# Altium schematic sheet Status_LEDs.SchDoc
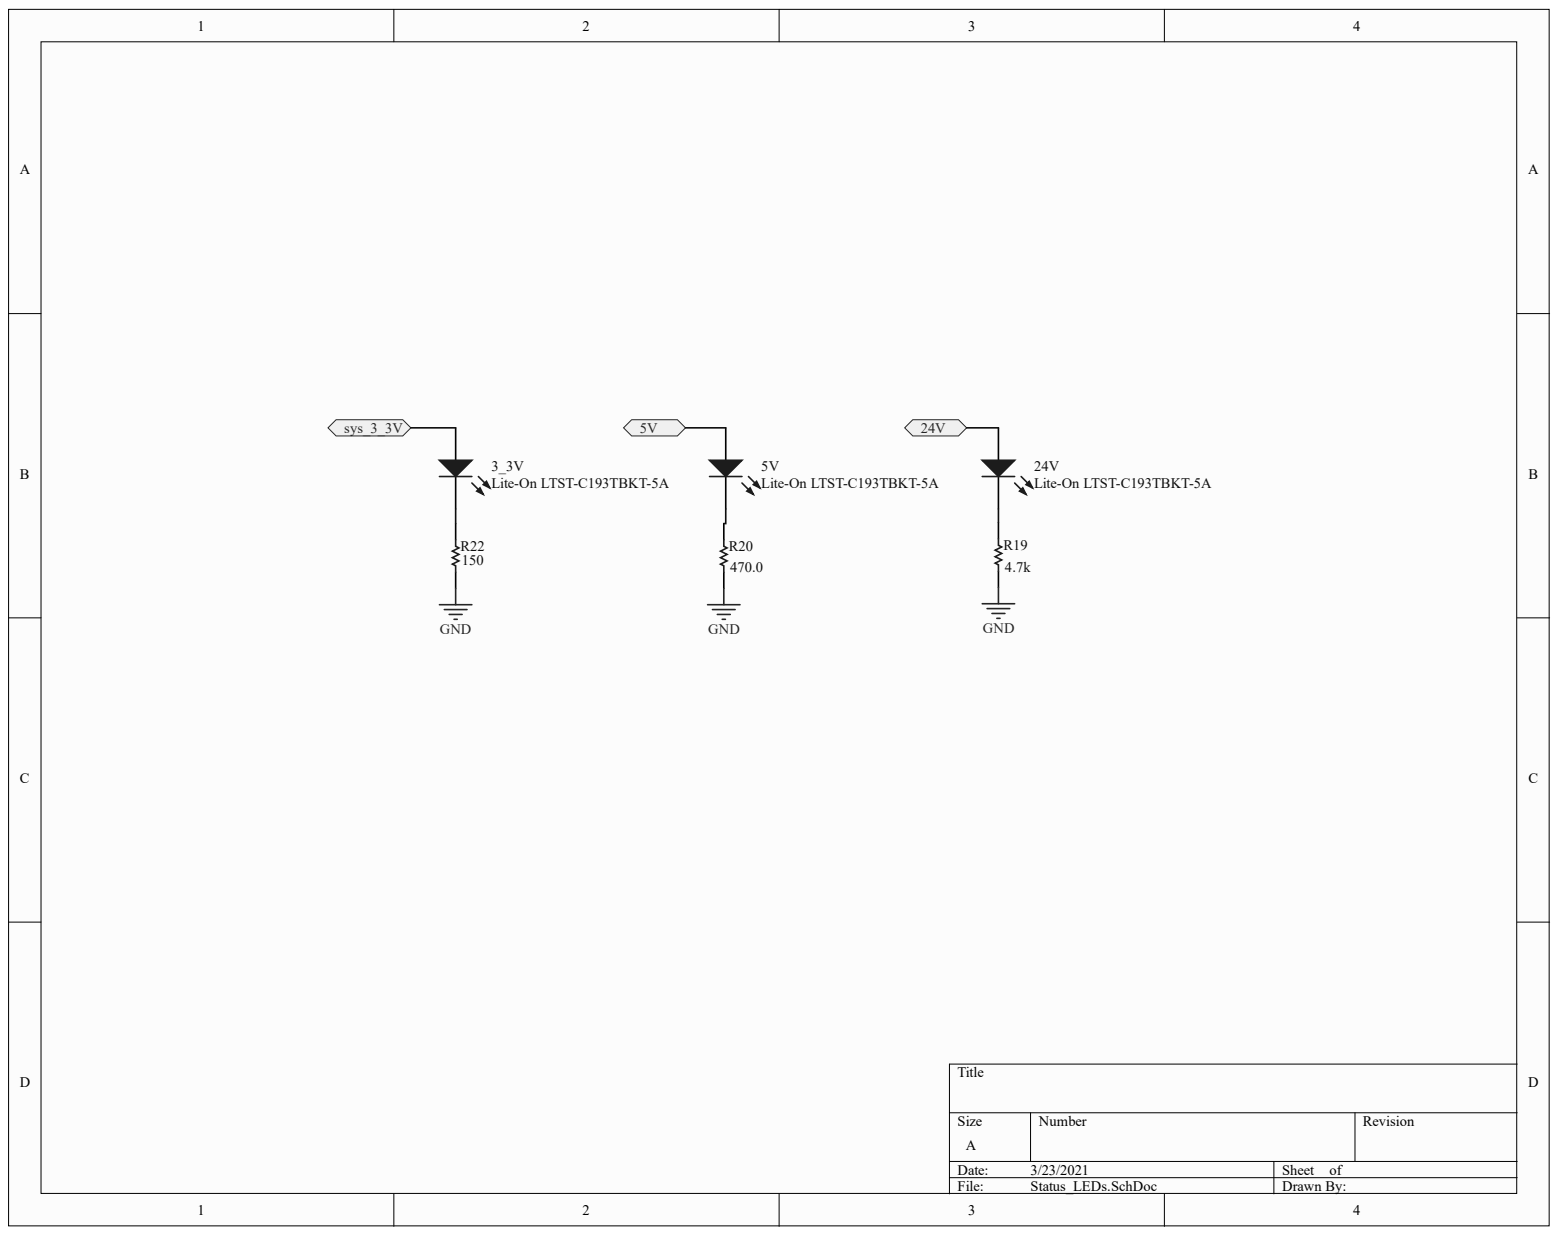
[diagram p0: Status_LEDs.SchDoc]

## Parts
3_3V  Lite-On LTST-C193TBKT-5A  [PCB-0603-BoxOverlay]
5V  Lite-On LTST-C193TBKT-5A  [PCB-0603-BoxOverlay]
24V  Lite-On LTST-C193TBKT-5A  [PCB-0603-BoxOverlay]
R19  value 4.7k  [PCB-g8ucq7vw1s2sdhfr4zem-1]  (hidden comment: Res1)
R20  value 470.0  [RESC1609X55X30ML10T20]  (hidden comment: Res1)
R22  value 150  [PCB-q471cgnjbrf9ki9faqwr-1]  (hidden comment: Res1)

## Ports
5V, 24V, SYS_3_3V

## Nets
GND: R19.1 R20.1 R22.1
SYS_3_3V: 3_3V.2 ; port SYS_3_3V
5V: 5V.2 ; port 5V
24V: 24V.2 ; port 24V
Net3_3V_1: 3_3V.1 R22.2
Net5V_1: 5V.1 R20.2
Net24V_1: 24V.1 R19.2

## Library data of the parts
3_3V 5V 24V = CMP-abba620d38e3747d-7: Blue 1.6 x 0.8 mm (0603) 130 º Intensity Min 11.2 mcd Typical 15 mcd SMT LED; Lead-Free Status: Lead Free; Colour: Blue; Component Kind: Standard; Library Name: CommunityVault; Manufacturer Part Number: LTST-C193TBKT-5A; OctopartID: abba620d38e3747d; Octopart URL: http://octopart.com/ltst-c193tbkt-5a-lite-on-12432959; RoHS: Compliant
R19 = CMP-011ec9310fadded9-4: Res Thick Film 0603 4.7K Ohm 1% 0.1W(1/10W) ±100ppm/C Pad SMD Automotive T/R; Resistance: 4.70 kΩ; Case/Package: 0603; Component Kind: Standard; Library Name: CommunityVault; Mounting Style: Surface Mount
R20 = CMP-120ade6ce4e1cdcd-1: Res Thick Film 0603 470 Ohm 5% 0.1W(1/10W) ±100ppm/C Pad SMD Automotive T/R; Resistance: 470 Ω; Temperature Coefficient: -100 ppm/°C to 100 ppm/°C; Voltage Rating (DC): 75.0 V; Component Kind: Standard; Library Name: CommunityVault; Lifecycle Status: Not Listed by Manufacturer; OctopartID: 120ade6ce4e1cdcd; Octopart URL: https://octopart.com/crgcq0603j470r-te+connectivity-91018125; Resistance Tolerance: ±5%; RoHS: Compliant
R22 = CMP-1853350-10: Res Thick Film 1.6 x 0.8 mm 150 Ohm 1% 0.1W(1/10W) 100ppm/ C Molded SMD Paper T/R; Manufacturer Part Number: RC0603FR-07150RL; Case/Package (SI): 1608; Lead-Free Status: Lead Free; Power Rating: 100 mW; Voltage Rating (DC): 50.0 V; Case/Package: 0603; Component Kind: Standard; Library Name: CommunityVault; Mounting Style: Surface Mount; Octopart URL: https://octopart.com/rc0603fr-07150rl-yageo-40952828; Packaging: Tape & Reel; REACH SVHC Compliance: No SVHC; Resistance Tolerance: ±1%; RoHS: Compliant; Size-Height: 450 µm; Size-Length: 1.60 mm; Size-Width: 800 µm
